# Altium schematic sheet ZYNQ_ETH_PHY.SchDoc(ZYNQ_ETH_PHY)
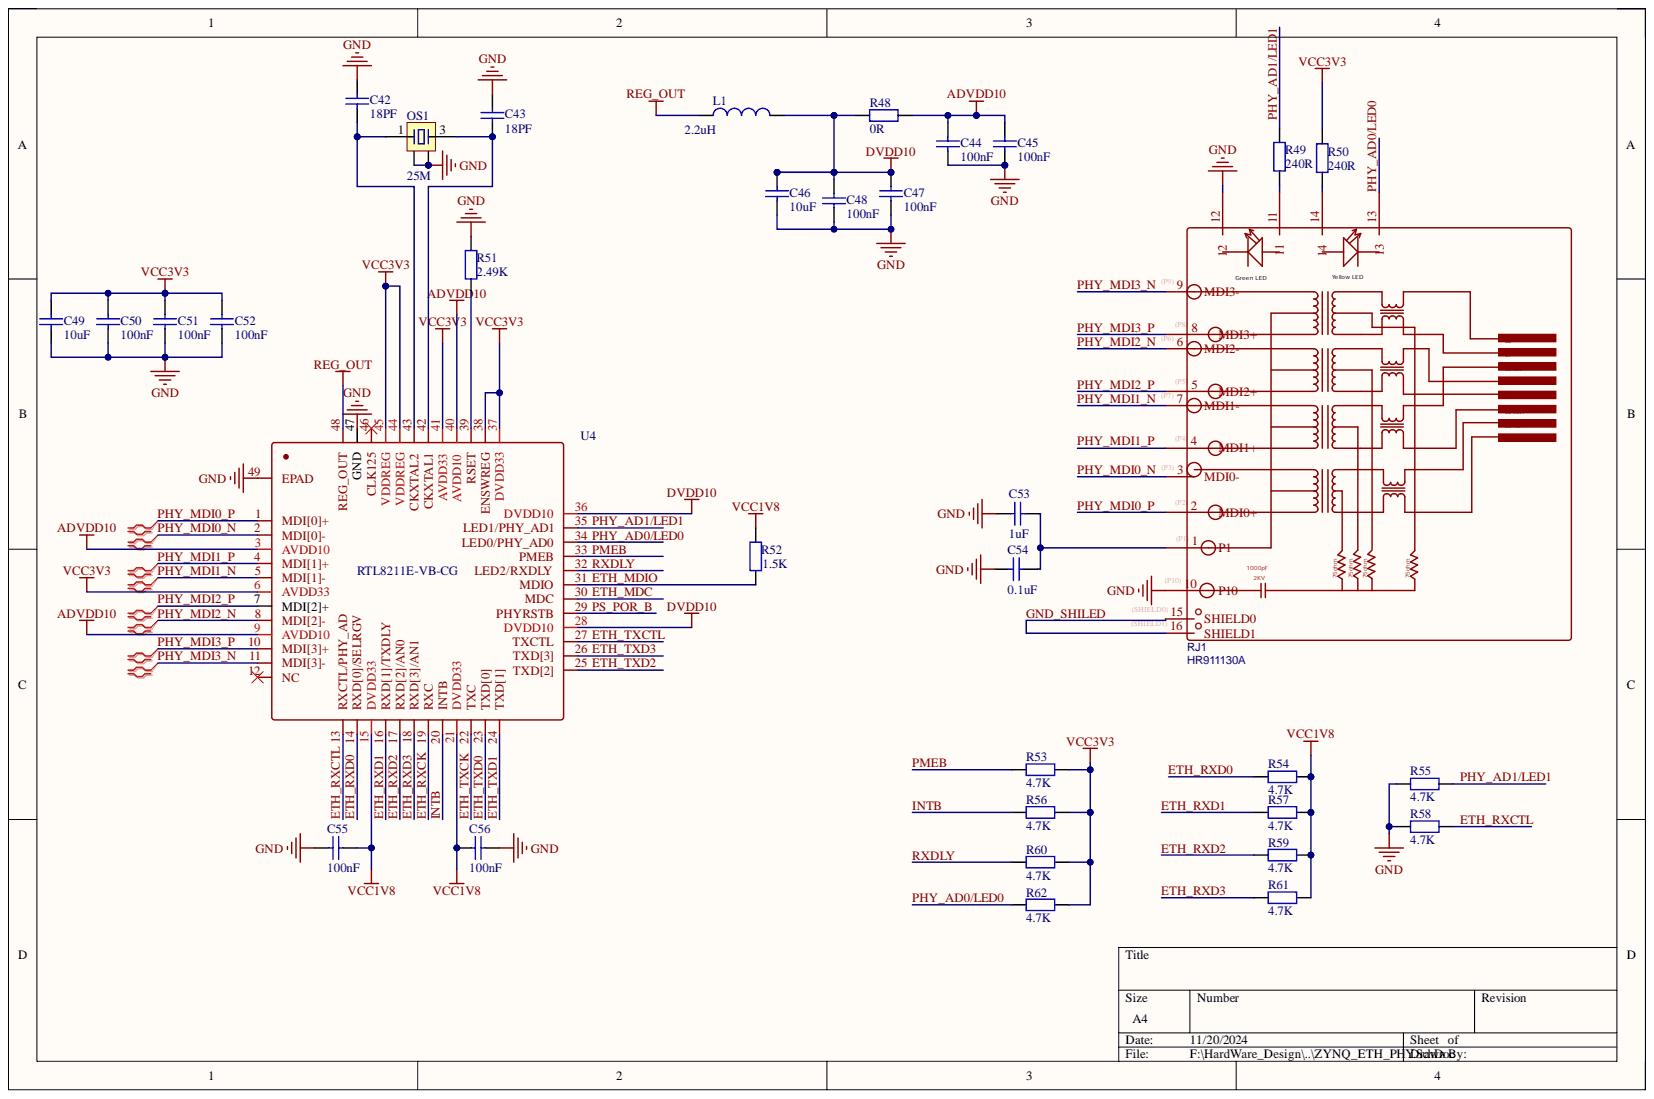
[diagram p0: ZYNQ_ETH_PHY.SchDoc]

## Parts
C42  18PF  [C 0402_L]
C43  18PF  [C 0402_L]
C44  100nF  [C 0402_L]
C45  100nF  [C 0402_L]
C46  10uF  [C 0603_L]
C47  100nF  [C 0402_L]
C48  100nF  [C 0402_L]
C49  10uF  [C 0603_L]
C50  100nF  [C 0402_L]
C51  100nF  [C 0402_L]
C52  100nF  [C 0402_L]
C53  1uF  [C 0402_L]
C54  0.1uF  [C 0402_L]
C55  100nF  [C 0402_L]
C56  100nF  [C 0402_L]
L1  2.2uH  [NR2520]
OS1  25M  [OSC 3225-4P]
R48  0R  [R 0402_L]
R49  240R  [R 0402_L]
R50  240R  [R 0402_L]
R51  2.49K  [R 0402_L]
R52  1.5K  [R 0402_L]
R53  4.7K  [R 0402_L]
R54  4.7K  [R 0402_L]
R55  4.7K  [R 0402_L]
R56  4.7K  [R 0402_L]
R57  4.7K  [R 0402_L]
R58  4.7K  [R 0402_L]
R59  4.7K  [R 0402_L]
R60  4.7K  [R 0402_L]
R61  4.7K  [R 0402_L]
R62  4.7K  [R 0402_L]
RJ1  HR911130A  [HR911130A]
U4  RTL8211E-VB-CG  [QFN40P600X600X100-49N]

## Nets
ADVDD10: C44.2 C45.2 R48.2 U4.3(AVDD10) U4.9(AVDD10) U4.40(AVDD10)
DVDD10: C46.2 C47.2 C48.2 L1.2 R48.1 U4.28(DVDD10) U4.36(DVDD10)
GND: C42.2 C43.2 C44.1 C45.1 C46.1 C47.1 C48.1 C49.1 C50.1 C51.1 C52.1 C53.1 C54.1 C55.2 C56.1 OS1.2 OS1.4 R51.2 R55.1 R58.1 RJ1.12 RJ1.P10 U4.47(GND) U4.49(EPAD)
REG_OUT: L1.1 U4.48(REG_OUT)
VCC1V8: C55.1 C56.2 R52.2 R54.2 R57.2 R59.2 R61.2 U4.15(DVDD33) U4.21(DVDD33)
VCC3V3: C49.2 C50.2 C51.2 C52.2 R50.2 R53.2 R56.2 R60.2 R62.2 U4.6(AVDD33) U4.37(DVDD33) U4.38(ENSWREG) U4.41(AVDD33) U4.44(VDDREG) U4.45(VDDREG)
ETH_MDC: U4.30(MDC)
ETH_MDIO: R52.1 U4.31(MDIO)
ETH_RXCK: U4.19(RXC)
ETH_RXCTL: R58.2 U4.13(RXCTL/PHY_AD)
ETH_RXD0: R54.1 U4.14(RXD[0]/SELRGV)
ETH_RXD1: R57.1 U4.16(RXD[1]/TXDLY)
ETH_RXD2: R59.1 U4.17(RXD[2]/AN0)
ETH_RXD3: R61.1 U4.18(RXD[3]/AN1)
ETH_TXCK: U4.22(TXC)
ETH_TXCTL: U4.27(TXCTL)
ETH_TXD0: U4.23(TXD[0])
ETH_TXD1: U4.24(TXD[1])
ETH_TXD2: U4.25(TXD[2])
ETH_TXD3: U4.26(TXD[3])
GND_SHILED: RJ1.SHIELD0 RJ1.SHIELD1
INTB: R56.1 U4.20(INTB)
PHY_AD0/LED0: R62.1 RJ1.13 U4.34(LED0/PHY_AD0)
PHY_AD1/LED1: R49.2 R55.2 U4.35(LED1/PHY_AD1)
PHY_MDI0_N: RJ1.P3(MDI0-) U4.2(MDI[0]-)
PHY_MDI0_P: RJ1.P2(MDI0+) U4.1(MDI[0]+)
PHY_MDI1_N: RJ1.P7(MDI1-) U4.5(MDI[1]-)
PHY_MDI1_P: RJ1.P4(MDI1+) U4.4(MDI[1]+)
PHY_MDI2_N: RJ1.P6(MDI2-) U4.8(MDI[2]-)
PHY_MDI2_P: RJ1.P5(MDI2+) U4.7(MDI[2]+)
PHY_MDI3_N: RJ1.P9(MDI3-) U4.11(MDI[3]-)
PHY_MDI3_P: RJ1.P8(MDI3+) U4.10(MDI[3]+)
PMEB: R53.1 U4.33(PMEB)
PS_POR_B: U4.29(PHYRSTB)
RXDLY: R60.1 U4.32(LED2/RXDLY)
NetC42_1: C42.1 OS1.1 U4.43(CKXTAL2)
NetC43_1: C43.1 OS1.3 U4.42(CKXTAL1)
NetC53_2: C53.2 C54.2 RJ1.P1
NetR49_1: R49.1 RJ1.11
NetR50_1: R50.1 RJ1.14
NetR51_1: R51.1 U4.39(RSET)

Not connected: U4 12(NC) 46(CLK125)

## Library data of the parts
C42-C52 C55 C56 = Cap1: Component Kind: Standard
  C42 C43: value: 18PF; Library Name: 零散元件库.SCHLIB
  C44 C45 C47 C48 C50-C52 C55 C56: value: 100nF
  C46 C49: value: 10uF
  C44-C52 C55 C56: Library Name: 零散元件库1.SCHLIB
C53 C54 = Cap: 贴片电容; Component Kind: Standard; Library Name: A_Schlib.SchLib
  C53: value: 1uF
  C54: value: 0.1uF
L1 = INDUCTOR: Component Kind: Standard; Library Name: 电感-SCH.Lib
OS1 = XTAL-4P: 4脚无源晶振; 版权归属: 封装库来自淘宝卖家 ID：黄晶宝石; BOM名称: 4脚无源晶振; Component Kind: Standard; Library Name: A_Schlib.SchLib; 学习资源: https://shop463601444.taobao.com
R48 R51-R62 = Res: Component Kind: Standard
  R48: value: 0R; Library Name: 零散元件库.SCHLIB
  R51: value: 2.49K
  R52: value: 1.5K
  R53-R62: value: 4.7K
  R51-R62: Library Name: 零散元件库1.SCHLIB
R49 R50 = Res: 通用; Component Kind: Standard; Library Name: A_Schlib.SchLib; value: 240R
RJ1 = HR911130A_1: Manufacturer: HANRUN(汉仁); Component Kind: Standard; JLCPCB Part Class: Extended Part; Library Name: SamacSys.SchLib; Manufacturer Part: HR911130A; spicePre: R; spiceSymbolName: HR911130A; Supplier: LCSC; Supplier Part: C54408
U4 = RTL8211E-VB-CG: Component Kind: Standard; Library Name: NGFF_M_Key_xc7z010-clg400.IntLib
